# Altium schematic sheet keyboard.SchDoc(keyboard)
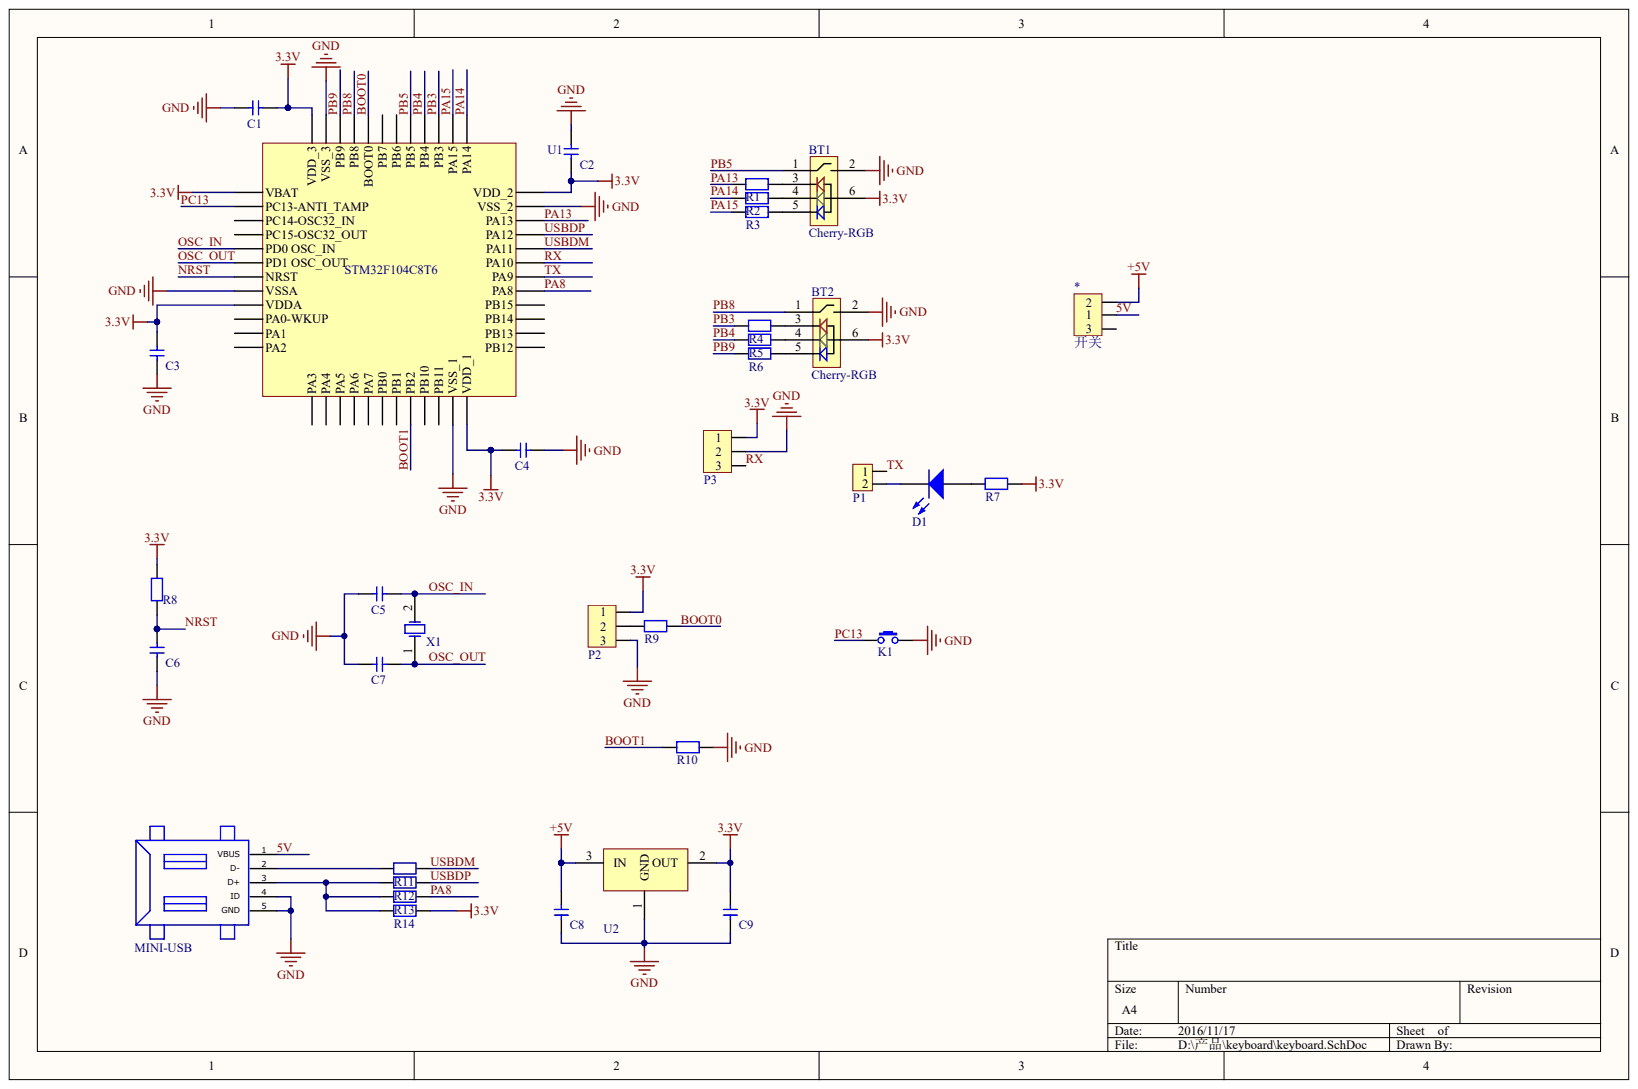
[diagram p0: keyboard.SchDoc]

## Parts
*  开关
BT1  Cherry-RGB
BT2  Cherry-RGB
C1  0603_CAP  (value not drawn)
C2  0603_CAP  (value not drawn)
C3  0603_CAP  (value not drawn)
C4  0603_CAP  (value not drawn)
C5  0603_CAP  (value not drawn)
C6  0603_CAP  (value not drawn)
C7  0603_CAP  (value not drawn)
C8  0603_CAP  (value not drawn)
C9  0603_CAP  (value not drawn)
D1  RGB  (value not drawn)
K1  SW-2A  (value not drawn)
MINI-USB  MINI-USB
P1  Header 2  (value not drawn)
P2  Header 3  (value not drawn)
P3  Header 3  (value not drawn)
R1  0603_RES  (value not drawn)
R2  0603_RES  (value not drawn)
R3  0603_RES  (value not drawn)
R4  0603_RES  (value not drawn)
R5  0603_RES  (value not drawn)
R6  0603_RES  (value not drawn)
R7  0603_RES  (value not drawn)
R8  0603_RES  (value not drawn)
R9  0603_RES  (value not drawn)
R10  0603_RES  (value not drawn)
R11  0603_RES  (value not drawn)
R12  0603_RES  (value not drawn)
R13  0603_RES  (value not drawn)
R14  0603_RES  (value not drawn)
U1  STM32F104C8T6
U2  AMS1117  (value not drawn)
X1  XTAL  (value not drawn)

## Nets
3.3V: BT1.6 BT2.6 C1.2 C2.1 C3.1 C4.2 C9.2 P2.1 P3.1 R7.2 R8.2 R14.2 U1.1(VBAT) U1.9(VDDA) U1.24(VDD_1) U1.36(VDD_2) U1.48(VDD_3) U2.2
+5V: *.2 C8.2 U2.3
GND: BT1.2 BT2.2 C1.1 C2.2 C3.2 C4.1 C5.1 C6.1 C7.1 C8.1 C9.1 K1.2 MINI-USB.4(ID) MINI-USB.5(GND) P2.3 P3.2 R10.2 U1.8(VSSA) U1.23(VSS_1) U1.35(VSS_2) U1.47(VSS_3) U2.1
5V: *.1 MINI-USB.1(VBUS)
BOOT0: R9.2 U1.44(BOOT0)
BOOT1: R10.1 U1.20(PB2)
NRST: C6.2 R8.1 U1.7(NRST)
OSC_IN: C5.2 U1.5(PD0_OSC_IN) X1.2
OSC_OUT: C7.2 U1.6(PD1_OSC_OUT) X1.1
PA8: R13.2 U1.29(PA8)
PA13: R1.1 U1.34(PA13)
PA14: R2.1 U1.37(PA14)
PA15: R3.1 U1.38(PA15)
PB3: R4.1 U1.39(PB3)
PB4: R5.1 U1.40(PB4)
PB5: BT1.1 U1.41(PB5)
PB8: BT2.1 U1.45(PB8)
PB9: R6.1 U1.46(PB9)
PC13: K1.1 U1.2(PC13-ANTI_TAMP)
RX: P3.3 U1.31(PA10)
TX: P1.1 U1.30(PA9)
USBDM: R11.2 U1.32(PA11)
USBDP: R12.2 U1.33(PA12)
NetBT1_3: BT1.3 R1.2
NetBT1_4: BT1.4 R2.2
NetBT1_5: BT1.5 R3.2
NetBT2_3: BT2.3 R4.2
NetBT2_4: BT2.4 R5.2
NetBT2_5: BT2.5 R6.2
NetD1_1: D1.1 R7.1
NetD1_2: D1.2 P1.2
NetMINI-USB_2: MINI-USB.2(D-) R11.1
NetMINI-USB_3: MINI-USB.3(D+) R12.1 R13.1 R14.1
NetP2_2: P2.2 R9.1

Not connected: * 3; U1 3(PC14-OSC32_IN) 4(PC15-OSC32_OUT) 10(PA0-WKUP) 11(PA1) 12(PA2) 13(PA3) 14(PA4) 15(PA5) 16(PA6) 17(PA7) 18(PB0) 19(PB1) 21(PB10) 22(PB11) 25(PB12) 26(PB13) 27(PB14) 28(PB15) 42(PB6) 43(PB7)
